# Altium schematic sheet CGQ1.SchDoc
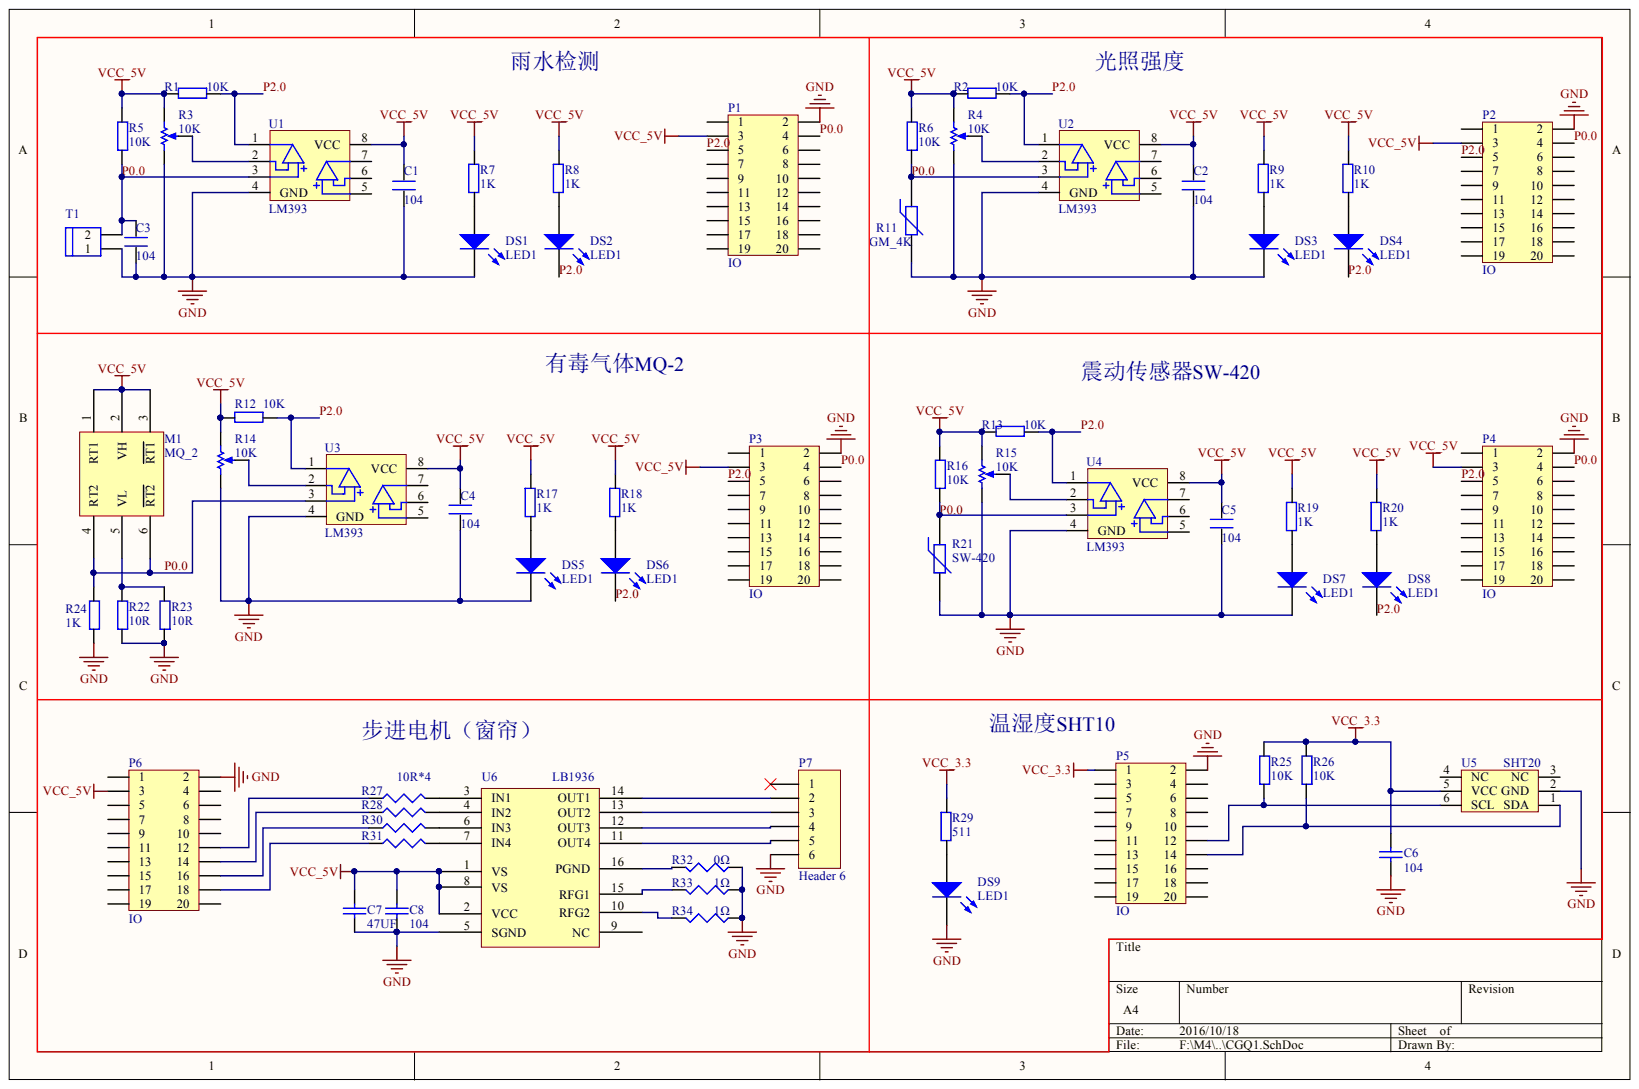
[diagram p0: CGQ1.SchDoc]

## Parts
C1  104  [0805]
C2  104  [0805]
C3  104  [0805]
C4  104  [0805]
C5  104  [0805]
C6  104  [0805]
C7  47UF  [1206]
C8  104  [0805]
DS1  LED1  [SMDLED]
DS2  LED1  [SMDLED]
DS3  LED1  [SMDLED]
DS4  LED1  [SMDLED]
DS5  LED1  [SMDLED]
DS6  LED1  [SMDLED]
DS7  LED1  [SMDLED]
DS8  LED1  [SMDLED]
DS9  LED1  [SMDLED]
M1  MQ_2  [MQ_2]
P1  IO  [SMA_P2X10]
P2  IO  [SMA_P2X10]
P3  IO  [SMA_P2X10]
P4  IO  [SMA_P2X10]
P5  IO  [SMA_P2X10]
P6  IO  [SMA_P2X10]
P7  Header 6  [BJDJ_4]
R1  10K  [0805]
R2  10K  [0805]
R3  10K  [SMA_DWQ]
R4  10K  [SMA_DWQ]
R5  10K  [0805]
R6  10K  [0805]
R7  1K  [0805]
R8  1K  [0805]
R9  1K  [0805]
R10  1K  [0805]
R11  GM_4K  [GM_CGQ]
R12  10K  [0805]
R13  10K  [0805]
R14  10K  [SMA_DWQ]
R15  10K  [SMA_DWQ]
R16  10K  [0805]
R17  1K  [0805]
R18  1K  [0805]
R19  1K  [0805]
R20  1K  [0805]
R21  SW-420  [SW_420]
R22  10R  [0805]
R23  10R  [0805]
R24  1K  [0805]
R25  10K  [0805]
R26  10K  [0805]
R27  10R*4  [0805]
R28  10R  [0805]  (value not drawn)
R29  511  [0805]
R30  10R  [0805]  (value not drawn)
R31  10R  [0805]  (value not drawn)
R32  0Ω  [0805]
R33  1Ω  [0805]
R34  1Ω  [0805]
T1  T1  [YD_CGQ]
U1  LM393  [SOP-8]
U2  LM393  [SOP-8]
U3  LM393  [SOP-8]
U4  LM393  [SOP-8]
U5  SHT20  [SHT20]
U6  LB1936  [TSSOP16]

## Nets
GND: C1.1 C2.1 C3.1 C4.1 C5.1 C6.2 C7.2 C8.2 DS1.2 DS3.2 DS5.2 DS7.2 DS9.2 P1.2 P2.2 P3.2 P4.2 P5.2 P6.2 P7.6 R3.1 R4.1 R11.2 R14.1 R15.1 R21.2 R22.1 R23.1 R24.1 R32.1 R33.1 R34.1 T1.1 U1.4(GND) U2.4(GND) U3.4(GND) U4.4(GND) U5.2(GND) U6.5(SGND)
VCC_3.3: C6.1 P5.1 R25.2 R26.2 R29.1 U5.5(VCC)
VCC_5V: C1.2 C2.2 C4.2 C5.2 C7.1 C8.1 M1.1(RT1) M1.2(VH) M1.3(RT1) P1.3 P2.3 P3.3 P4.3 P6.3 R1.2 R2.2 R3.2 R4.2 R5.2 R6.2 R7.1 R8.1 R9.1 R10.1 R12.2 R13.2 R14.2 R15.2 R16.2 R17.1 R18.1 R19.1 R20.1 U1.8(VCC) U2.8(VCC) U3.8(VCC) U4.8(VCC) U6.1(VS) U6.2(VCC) U6.8(VS)
P0.0: C3.2 M1.4(RT2) M1.6(RT2) P1.4 P2.4 P3.4 P4.4 R5.1 R6.1 R11.1 R16.1 R21.1 R24.2 T1.2 U1.3 U2.3 U3.3 U4.3
P2.0: DS2.2 DS4.2 DS6.2 DS8.2 P1.5 P2.5 P3.5 P4.5 R1.1 R2.1 R12.1 R13.1 U1.1 U2.1 U3.1 U4.1
NetDS1_1: DS1.1 R7.2
NetDS2_1: DS2.1 R8.2
NetDS3_1: DS3.1 R9.2
NetDS4_1: DS4.1 R10.2
NetDS5_1: DS5.1 R17.2
NetDS6_1: DS6.1 R18.2
NetDS7_1: DS7.1 R19.2
NetDS8_1: DS8.1 R20.2
NetDS9_1: DS9.1 R29.2
NetM1_5: M1.5(VL) R22.2 R23.2
NetP5_12: P5.12 R25.1 U5.6(SCL)
NetP5_14: P5.14 R26.1 U5.1(SDA)
NetP6_12: P6.12 R27.1
NetP6_14: P6.14 R28.1
NetP6_16: P6.16 R30.1
NetP6_18: P6.18 R31.1
NetP7_2: P7.2 U6.14(OUT1)
NetP7_3: P7.3 U6.13(OUT2)
NetP7_4: P7.4 U6.12(OUT3)
NetP7_5: P7.5 U6.11(OUT4)
NetR3_3: R3.3 U1.2
NetR4_3: R4.3 U2.2
NetR14_3: R14.3 U3.2
NetR15_3: R15.3 U4.2
NetR27_2: R27.2 U6.3(IN1)
NetR28_2: R28.2 U6.4(IN2)
NetR30_2: R30.2 U6.6(IN3)
NetR31_2: R31.2 U6.7(IN4)
NetR32_2: R32.2 U6.16(PGND)
NetR33_2: R33.2 U6.15(RFG1)
NetR34_2: R34.2 U6.10(RFG2)

Not connected: P1 1 6 7 8 9 10 11 12 13 14 15 16 17 18 19 20; P2 1 6 7 8 9 10 11 12 13 14 15 16 17 18 19 20; P3 1 6 7 8 9 10 11 12 13 14 15 16 17 18 19 20; P4 1 6 7 8 9 10 11 12 13 14 15 16 17 18 19 20; P5 3 4 5 6 7 8 9 10 11 13 15 16 17 18 19 20; P6 1 4 5 6 7 8 9 10 11 13 15 17 19 20; P7 1; U1 5 6 7; U2 5 6 7; U3 5 6 7; U4 5 6 7; U5 3(NC) 4(NC); U6 9(NC)

## Library data of the parts
C1-C5 = C: Component Kind: Standard; Library Name: EXPLORER.SCHLIB
C6-C8 = Cap__: Value: 100pF; Component Kind: Standard; LatestRevisionDate: 29-May-2009; LatestRevisionNote: IPC-7351 Footprint Added.; Library Name: Schlib1.SchLib; PackageDescription: Chip Capacitor; PackageReference: C0805; Published: 8-Jun-2000; Publisher: Altium Limited
DS1-DS9 = LED2: Typical RED, GREEN, YELLOW, AMBER GaAs LED; Component Kind: Standard; LatestRevisionDate: 17-Jul-2002; LatestRevisionNote: Re-released for DXP Platform.; Library Name: WARSHIP.SCHLIB; PackageDocument: Feb-2002; PackageReference: 3.2X1.6X1.1; Published: 8-Jun-2000; Simulation: LED2; Publisher: Altium Limited
P7 = Header 6: Header, 6-Pin; Component Kind: Standard; LatestRevisionDate: 17-Jul-2002; LatestRevisionNote: Re-released for DXP Platform.; Library Name: Miscellaneous Connectors.IntLib; Signal Integrity: Connector; Publisher: Altium Limited
R1 R2 R5-R10 R12 R13 R16-R20 R22-R26 R29 = R: Component Kind: Standard
  R1 R2 R5-R10 R12 R13 R16-R20 R25 R26 R29: Library Name: EXPLORER.SCHLIB
  R22-R24: Library Name: Explorer STM32F407封装库.IntLib
R3 R4 R14 R15 = RPot: Potentiometer; Set Position: 0.5; Sim Note: Enter the Resistance value and the set point into parameter fields.; Value: 1K; Component Kind: Standard; LatestRevisionDate: 17-Jul-2002; LatestRevisionNote: Re-released for DXP Platform.; Library Name: Miscellaneous Devices.IntLib; PackageDescription: Variable Resistor, Thru-Hole; 3 Leads; PackageReference: VR5; Published: 8-Jun-2000; Simulation: POT; Publisher: Altium Limited
R11 R21 = Res Varistor: Varistor (Voltage-Sensitive Resistor); Code_IPC: RESC2012; Component Kind: Standard; LatestRevisionDate: 29-May-2009; LatestRevisionNote: IPC-7351 Footprint Added.; Library Name: Miscellaneous Devices.IntLib; PackageDescription: Chip Resistor 0805 Case Size; PackageReference: 6-0805; PackageVersion: Mar-2003; Published: 24-Mar-1999; Publisher: Altium Limited
R27 R28 R30-R34 = Res1: Resistor; Value: 1K; Component Kind: Standard; LatestRevisionDate: 29-May-2009; LatestRevisionNote: IPC-7351 Footprint Added.; Library Name: Schlib1.SchLib; PackageDescription: Chip Resistor; PackageReference: J1-0603; Published: 8-Jun-2000; Publisher: Altium Limited
T1 = HEAD2: Component Kind: Standard; Library Name: EXPLORER.SCHLIB
M1 = MQ_2: Component Kind: Standard; Library Name: Schlib1.SchLib
P1-P6 = IO: Component Kind: Standard; Library Name: ZGB_Project.SCHLIB
U1-U4 = LM393: Component Kind: Standard; Library Name: Schlib1.SchLib
U5 = SHT20: Component Kind: Standard; Library Name: zgb.SchLib
U6 = LB1936: Component Kind: Standard; Library Name: Schlib1.SchLib
